# Altium schematic sheet TEMP.SchDoc(TEMP)
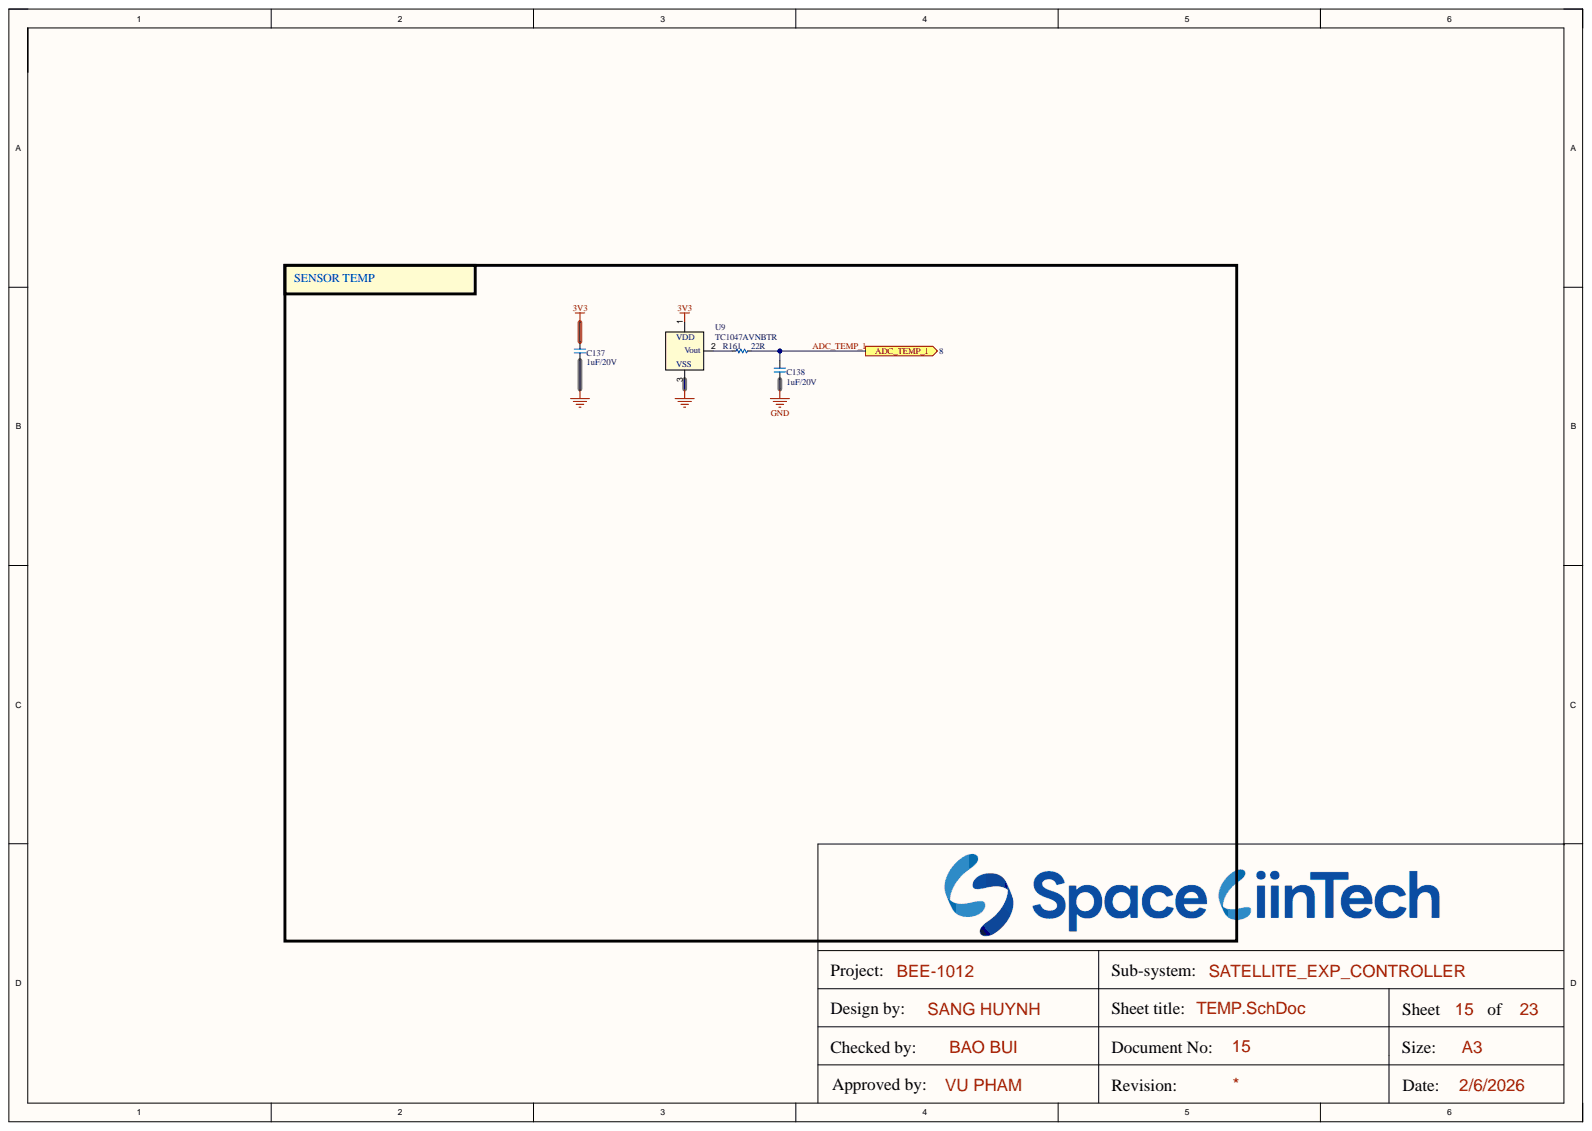
[diagram p0: TEMP.SchDoc]

## Parts
C137  1uF/20V  [CAP_C_1005X50_L]
C138  1uF/20V  [CAP_C_1005X50_L]
R161  22R  [RES_C_1005x30_L]
U9  TC1047AVNBTR  [SOT-23_MC_MCH]

## Ports
ADC_TEMP_1

## Nets
3V3: C137.2 U9.1
GND: C137.1 C138.1 U9.3
ADC_TEMP_1: C138.2 R161.2 ; port ADC_TEMP_1
NetR161_1: R161.1 U9.2

## Library data of the parts
C137 C138 = _CAP_C_2: CAP CHIP; Component Kind: Standard; Library Name: IFBschelib.SchLib
R161 = _RES_B_2: RES; Component Kind: Standard; Library Name: IFBschelib.SchLib
U9 = TC1047AVNBTR: SENSOR ANALOG -40C-125C SOT23-3; Manufacturer_Name: Microchip; Component Kind: Standard; Manufacturer_Part_Number: TC1047AVNBTR; RefDes: RefDes; Type: Type; Copyright: Copyright (C) 2025 Ultra Librarian. All rights reserved.; Library Name: IFBschelib.SchLib
